# Altium schematic sheet 1 - Connectors.SchDoc
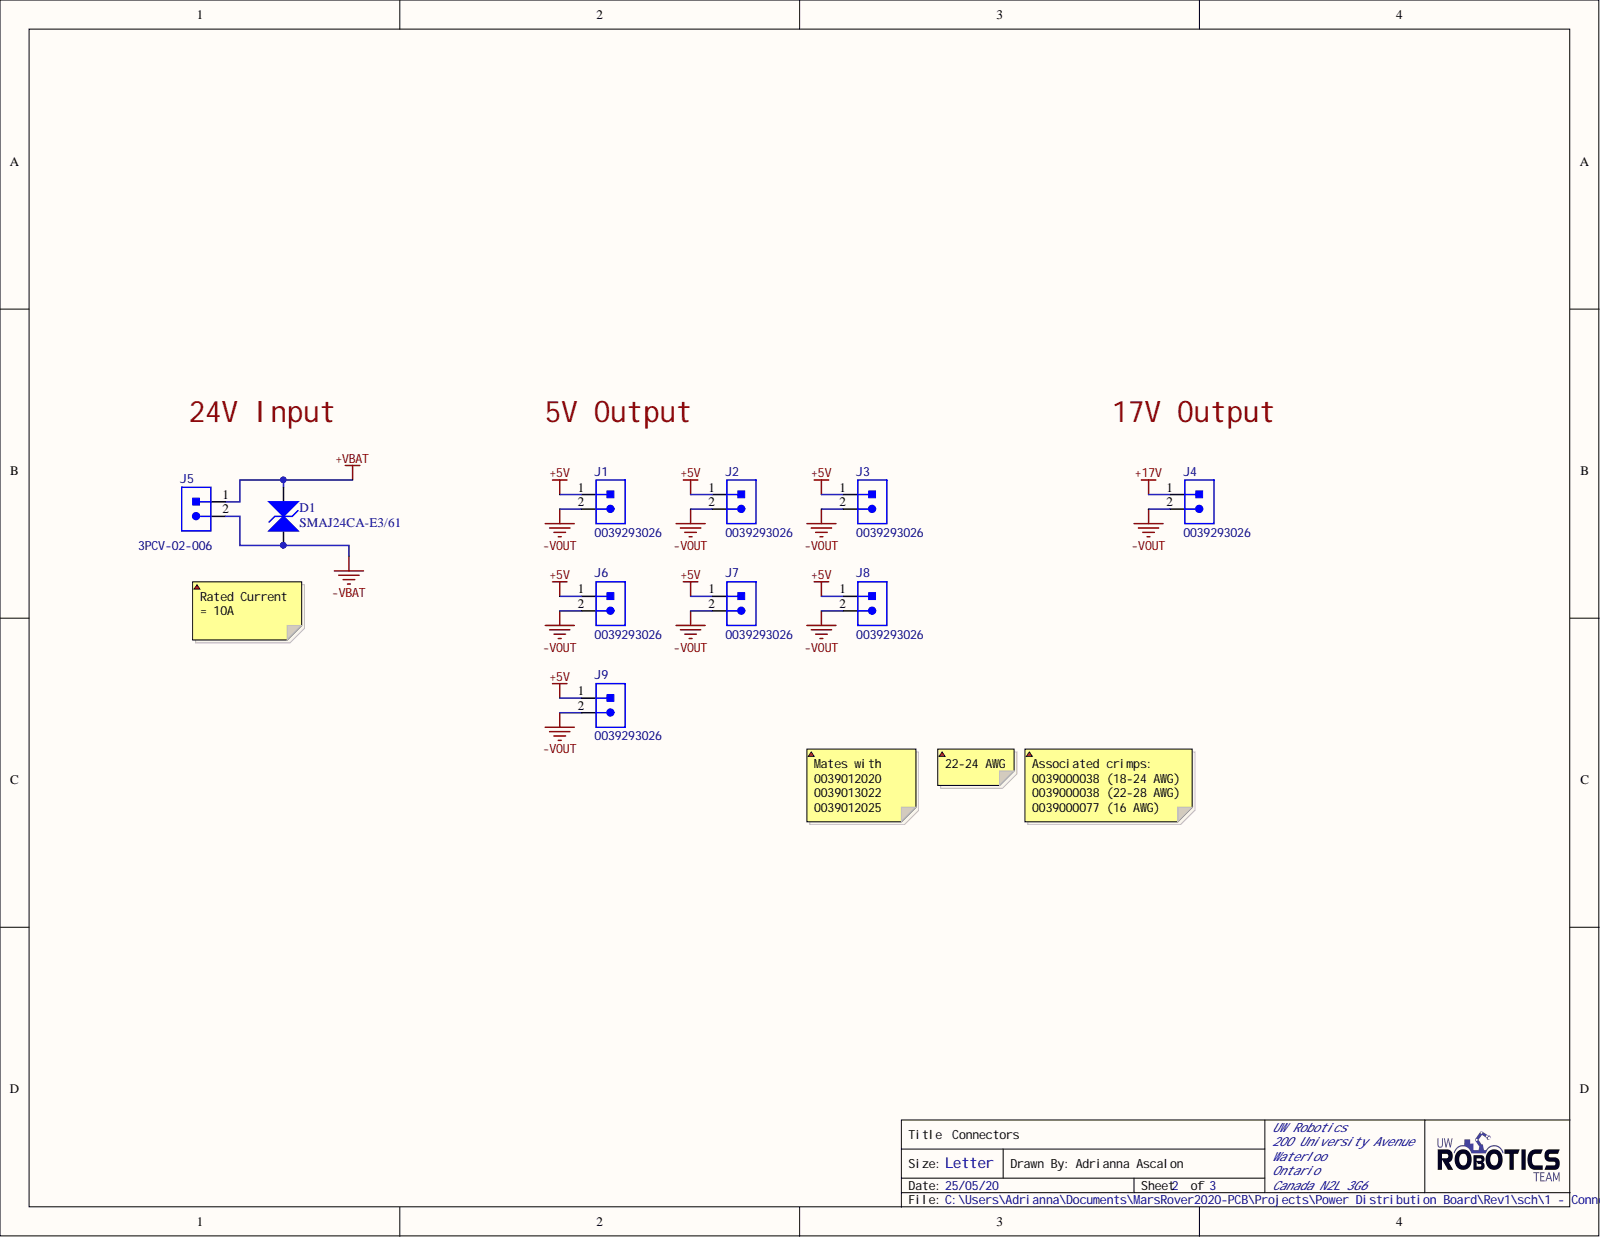
[diagram p0: 1 - Connectors.SchDoc]

## Parts
D1  SMAJ24CA-E3/61
J1  0039293026
J2  0039293026
J3  0039293026
J4  0039293026
J5  3PCV-02-006
J6  0039293026
J7  0039293026
J8  0039293026
J9  0039293026

## Nets
+5V: J1.1 J2.1 J3.1 J6.1 J7.1 J8.1 J9.1
+17V: J4.1
+VBAT: D1.2 J5.1
-VBAT: D1.1 J5.2
-VOUT: J1.2 J2.2 J3.2 J4.2 J6.2 J7.2 J8.2 J9.2

## Library data of the parts
D1 = SMAJ24CA-E3/61: TVS DIODE, 400W, 24V, DO-214AC; Manufacturer 1: Vishay Semiconductors; Breakdown Voltage: 26.7V; Clamping Voltage: 38.9V; Direction: Bidirectional; Element Configuration: Single; Leakage Current: 1uA; Max Breakdown Voltage: 29.5V; Max Forward Surge Current (Ifsm): 40A; Max Surge Current: 10.3A; Number of Bidirectional Channels: 1; Power Line Protection: No; Test Current: 1mA; Case/Package: SMA; Component Kind: Standard; ComponentLink1Description: https://datasheet.ciiva.com/15143/getdatasheetpartid-35124-15143026.pdf?src-supplier=Verical; ComponentLink1URL: https://datasheet.ciiva.com/15143/getdatasheetpartid-35124-15143026.pdf?src-supplier=Verical; ComponentLink2Description: https://datasheet.ciiva.com/4247/2smaj50a-4247844.pdf?src-supplier=Arrow; ComponentLink2URL: https://datasheet.ciiva.com/4247/2smaj50a-4247844.pdf?src-supplier=Arrow; ComponentLink3Description: https://datasheet.ciiva.com/4509/smaj50a-241759-4509561.pdf?src-supplier=Mouser; ComponentLink3URL: https://datasheet.ciiva.com/4509/smaj50a-241759-4509561.pdf?src-supplier=Mouser; ComponentLink4Description: https://datasheet.ciiva.com/22637/548547-22637055.pdf?src-supplier=Element14; ComponentLink4URL: https://datasheet.ciiva.com/22637/548547-22637055.pdf?src-supplier=Element14; Composition: Zener; Contact Plating: Tin,Matte; Height: 0.09inch; Lead Free: Lead Free; Length: 0.177inch; Manufacturer Part Number 1: SMAJ24CA-E3/61; Max Operating Temperature: 150°C; Max Power Dissipation: 400W; Max Reverse Leakage Current: 1uA; Min Breakdown Voltage: 26.7V; Min Operating Temperature: -55°C; Number of Channels: 1; Number of Elements: 1; Number of Pins: 2; Operating Supply Voltage: 24V; Package Quantity: 1800; Packaging: Tape and Reel; Peak Pulse Current: 10.3A; Peak Pulse Power: 400W; Polarity: Bidirectional; Power Dissipation: 400W; Pricing 1: 1=0.35000, 10=0.28400, 25=0.26000, 100=0.16340, 250=0.16152, 500=0.15126 (USD); Radiation Hardening: No; REACH SVHC: Unknown; Reverse Breakdown Voltage: 26.7V; Reverse Standoff Voltage: 24V; RoHS: Yes; Stock 1: 6243; Supplier 1: Digi-Key; Supplier Part Number 1: SMAJ24CA-E3/61GICT-ND; Termination: SMD/SMT; Voltage Rating (DC): 24V; Weight: 0.064g; Width: 0.11inch; Library Name: UWRT_Schematic_Library_2020.SchLib
J1-J4 J6-J9 = 0039293026: Conn Wire to Board HDR 2 POS Solder ST Thru-Hole Mini-Fit Jr™ Bag; Manufacturer 1: Molex; Connector Type: Male Pin; Contact Resistance: 10mR; Gender: Male; Number of Contacts: 2; Pitch: 4.2mm; Row Spacing: 0.165inch; Color: Natural; Component Kind: Standard; ComponentLink1Description: https://datasheet.ciiva.com/3117/0039293026-pcb-headers-3117298.pdf?src-supplier=Avnet; ComponentLink1URL: https://datasheet.ciiva.com/3117/0039293026-pcb-headers-3117298.pdf?src-supplier=Avnet; ComponentLink2Description: https://datasheet.ciiva.com/26921/getdatasheetpartid-310796-26921947.pdf?src-supplier=Verical; ComponentLink2URL: https://datasheet.ciiva.com/26921/getdatasheetpartid-310796-26921947.pdf?src-supplier=Verical; ComponentLink3Description: https://datasheet.ciiva.com/18486/039293026-sd-18486507.pdf?src-supplier=Digi-Key; ComponentLink3URL: https://datasheet.ciiva.com/18486/039293026-sd-18486507.pdf?src-supplier=Digi-Key; Contact Material: Brass; Contact Plating: Tin,Copper,Bright; Depth: 11mm; Fastening Type: Ramp; Housing Material: Nylon; Length: 5.8mm; Manufacturer Part Number 1: 0039293026; Max Operating Temperature: 105°C; Min Operating Temperature: -40°C; Mount: Through Hole; Number of Positions: 2; Number of Rows: 2; Orientation: Straight; Packaging: Bulk; Pricing 1: 1=0.54000, 10=0.50400, 100=0.38660, 500=0.33620, 1000=0.27737, 5000=0.24375, 10000=0.22694 (USD); RoHS: Yes; Stock 1: 23064; Supplier 1: Digi-Key; Supplier Part Number 1: WM3843-ND; Termination: Solder; Library Name: UWRT_Schematic_Library_2020.SchLib
J5 = 3PCV-02-006: Barrier Terminal Blocks TRI-BARRIER .250 2P; Manufacturer 1: TE Connectivity; Current Rating: 10A; Mounting Ears: No (Without); Number of Circuits: 2; Number of Levels: 1; Pitch: 6.35mm; Thread Size: M3; Voltage: 300V; Wire Gauge (Max): 16AWG; Wire Gauge (Min): 30AWG; Color: Black; Component Kind: Standard; ComponentLink1Description: https://datasheet.ciiva.com/30058/eng-cs-1308389-barrier-strips-0607-30058410.pdf?src-supplier=Onlinecomponents.com; ComponentLink1URL: https://datasheet.ciiva.com/30058/eng-cs-1308389-barrier-strips-0607-30058410.pdf?src-supplier=Onlinecomponents.com; ComponentLink2Description: https://datasheet.ciiva.com/9377/eng-ds-1-1773458-2-barrier-strips-qrg-0810-9377275.pdf?src-supplier=Avnet; ComponentLink2URL: https://datasheet.ciiva.com/9377/eng-ds-1-1773458-2-barrier-strips-qrg-0810-9377275.pdf?src-supplier=Avnet; Contact Material: Copper Alloy; Contact Plating: Tin; ELV: Compliant; Flammability Rating: UL94 V-0; Height: 0.5inch; Housing Color: Black; Housing Material: Thermoplastic; Length: 0.55inch; Manufacturer Part Number 1: 3PCV-02-006; Max Current Rating: 10A; Mount: Through Hole; Mounting Insert: No (Without); Number of Contacts: 2; Number of Positions: 2; Number of Rows: 1; Orientation: Straight; Package Quantity: 1000; Pricing 1: 1=1.78000, 10=1.25100, 25=1.16720, 50=1.05920, 100=1.05460, 250=0.89052, 500=0.84364, 1000=0.74990, 5000=0.60930 (USD); Radiation Hardening: No; RoHS: Yes; Stock 1: 3086; Supplier 1: Digi-Key; Terminal Material: Copper Alloy; Termination: Solder; Voltage Rating: 300V; Width: 0.55inch; Library Name: UWRT_Schematic_Library_2020.SchLib
